# Altium schematic sheet sheet2_connectors.SchDoc
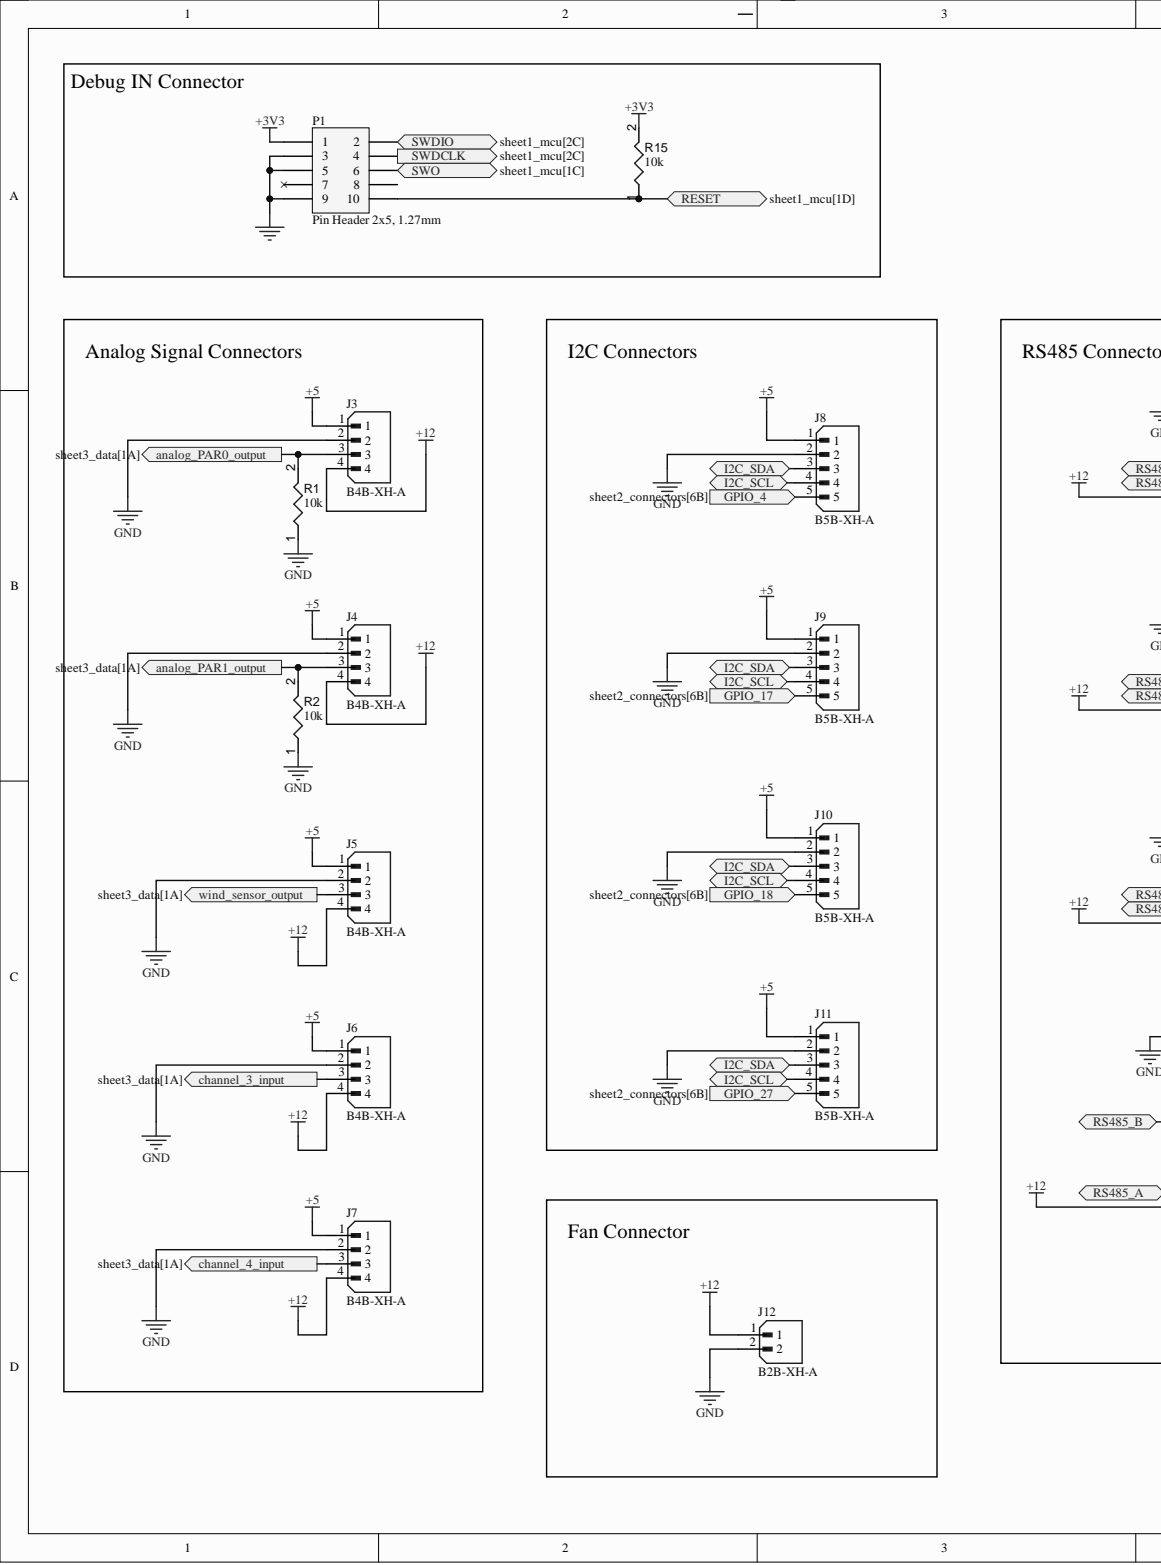
[diagram p0: sheet2_connectors.SchDoc, left]
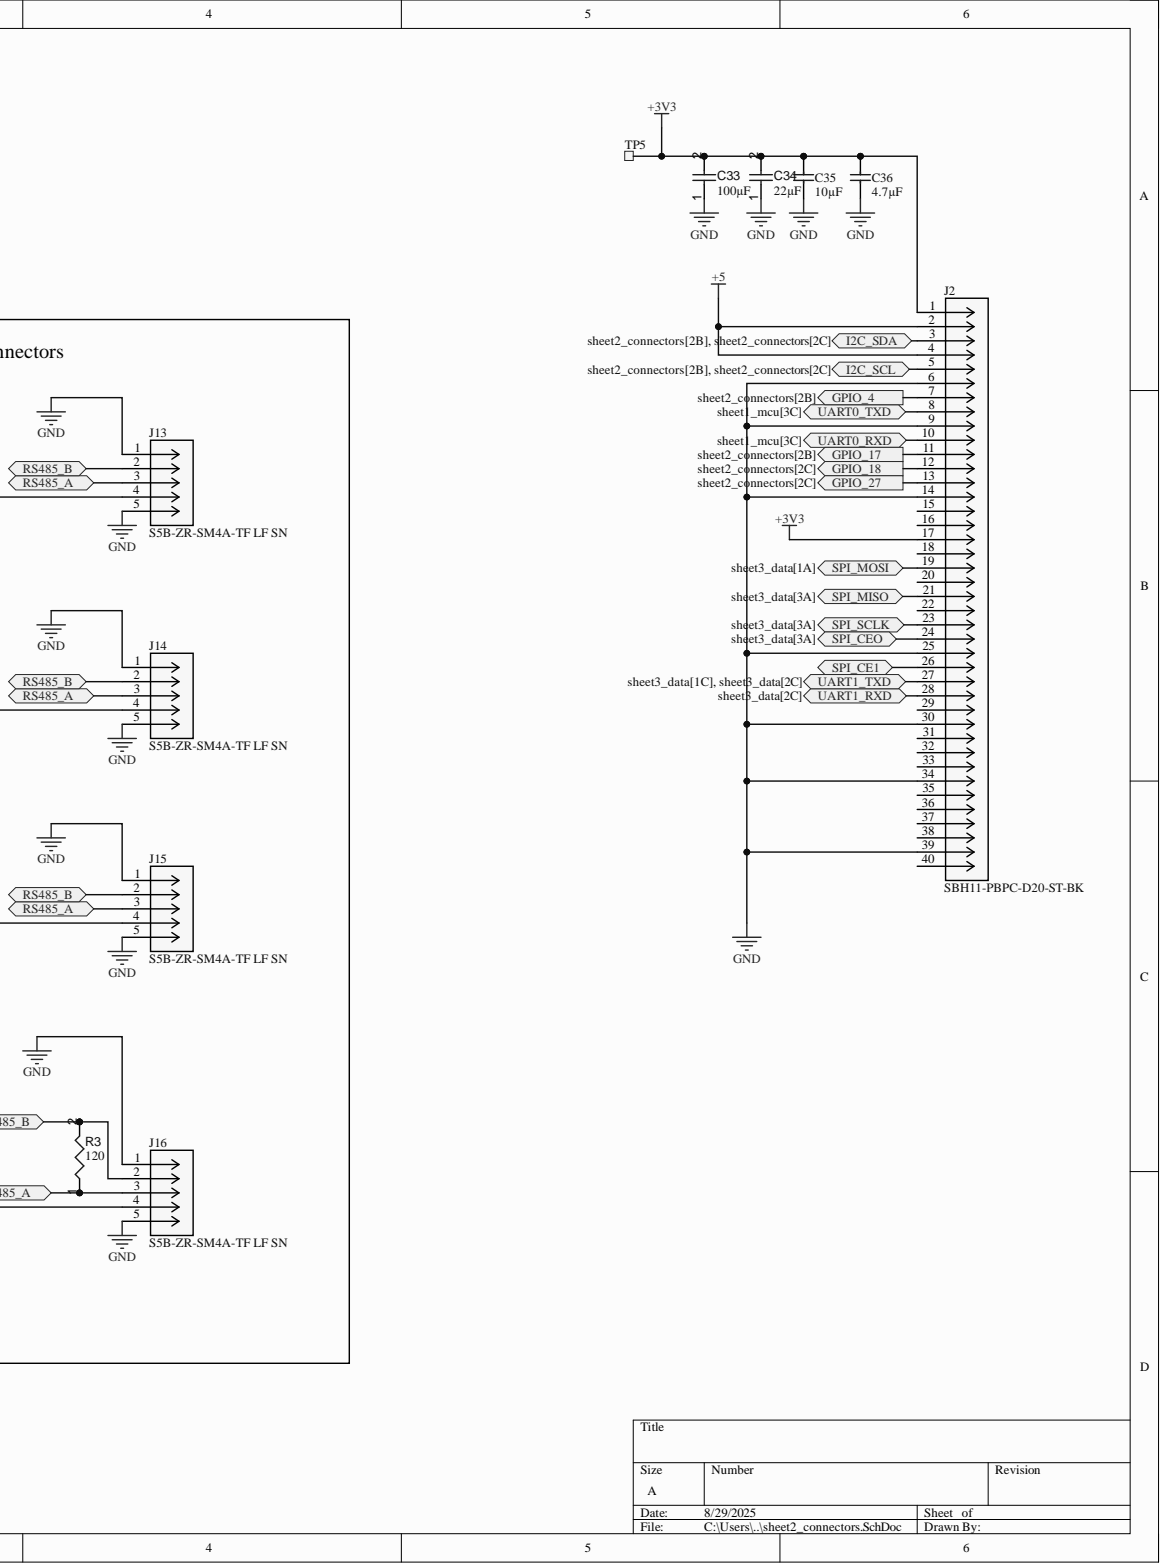
[diagram p1: sheet2_connectors.SchDoc, right]

## Parts
C33  value 100µF  [CAPC0805(2012)145_L]  (hidden comment: Capacitor 100µF +/-20% 6.3V 0805)
C34  value 22µF  [CAPC0805(2012)145_L]  (hidden comment: Capacitor 22µF +/-40% 16V 0805)
C35  10µF  [CAPC1608X06L]
C36  4.7µF  [CAPC1608X06L]
J2  SBH11-PBPC-D20-ST-BK  [CONN40_SBH11-PBPC-D20-ST-BK_SUL]
J3  B4B-XH-A  [JST_B4B-XH-A]
J4  B4B-XH-A  [JST_B4B-XH-A]
J5  B4B-XH-A  [JST_B4B-XH-A]
J6  B4B-XH-A  [JST_B4B-XH-A]
J7  B4B-XH-A  [JST_B4B-XH-A]
J8  B5B-XH-A  [JST_B5B-XH-A]
J9  B5B-XH-A  [JST_B5B-XH-A]
J10  B5B-XH-A  [JST_B5B-XH-A]
J11  B5B-XH-A  [JST_B5B-XH-A]
J12  B2B-XH-A  [JST_B2B-XH-A]
J13  S5B-ZR-SM4A-TF LF SN  [CONN_S5B-ZR-SM4A-TF_JST]
J14  S5B-ZR-SM4A-TF LF SN  [CONN_S5B-ZR-SM4A-TF_JST]
J15  S5B-ZR-SM4A-TF LF SN  [CONN_S5B-ZR-SM4A-TF_JST]
J16  S5B-ZR-SM4A-TF LF SN  [CONN_S5B-ZR-SM4A-TF_JST]
P1  Pin Header 2x5, 1.27mm  [HDR_2x5-SMD-1.27mm-K]
R1  value 10k  [RESC1005X40X25ML05T05]  (hidden comment: Resistor 10k +/-1% 0402 63 mW)
R2  value 10k  [RESC1005X40X25ML05T05]  (hidden comment: Resistor 10k +/-1% 0402 63 mW)
R3  value 120  [RESC0603(1608)_L]  (hidden comment: Resistor 120R +/-5 % 0603 0.1 W)
R15  value 10k  [RESC0402(1005)_L]  (hidden comment: Resistor 10K  +/-1 % 0402 63 mW)
TP5  Ø1.0mm,SMD  [TP_SMD_D1.0mm]  (value not drawn)  (no BOM)

## Ports
analog_PAR0_output, analog_PAR1_output, channel_3_input, channel_4_input, GPIO_4, GPIO_17, GPIO_18, GPIO_27, I2C_SCL, I2C_SDA, RESET, RS485_A, RS485_B, SPI_CE1, SPI_CEO, SPI_MISO, SPI_MOSI, SPI_SCLK, SWDCLK, SWDIO, SWO, UART0_RXD, UART0_TXD, UART1_RXD, UART1_TXD, wind_sensor_output

## Nets
+3V3: C33.2 C34.2 C35.2 C36.2 J2.1 J2.17 P1.1 R15.2 TP5.1
+5: J2.2 J2.4 J3.1 J4.1 J5.1 J6.1 J7.1 J8.1 J9.1 J10.1 J11.1
+12: J3.4 J4.4 J5.4 J6.4 J7.4 J12.1 J13.4 J14.4 J15.4 J16.4
GND: C33.1 C34.1 C35.1 C36.1 J2.6 J2.9 J2.14 J2.25 J2.30 J2.34 J2.39 J3.2 J4.2 J5.2 J6.2 J7.2 J8.2 J9.2 J10.2 J11.2 J12.2 J13.1 J13.5 J14.1 J14.5 J15.1 J15.5 J16.1 J16.5 P1.3 P1.5 P1.9 R1.1 R2.1
analog_PAR0_output: J3.3 R1.2 ; port analog_PAR0_output
analog_PAR1_output: J4.3 R2.2 ; port analog_PAR1_output
channel_3_input: J6.3 ; port channel_3_input
channel_4_input: J7.3 ; port channel_4_input
GPIO_4: J2.7 J8.5 ; port GPIO_4
GPIO_17: J2.11 J9.5 ; port GPIO_17
GPIO_18: J2.12 J10.5 ; port GPIO_18
GPIO_27: J2.13 J11.5 ; port GPIO_27
I2C_SCL: J2.5 J8.4 J9.4 J10.4 J11.4 ; port I2C_SCL
I2C_SDA: J2.3 J8.3 J9.3 J10.3 J11.3 ; port I2C_SDA
RESET: P1.10 R15.1 ; port RESET
RS485_A: J13.3 J14.3 J15.3 J16.3 R3.1 ; port RS485_A
RS485_B: J13.2 J14.2 J15.2 J16.2 R3.2 ; port RS485_B
SPI_CE1: J2.26 ; port SPI_CE1
SPI_CEO: J2.24 ; port SPI_CEO
SPI_MISO: J2.21 ; port SPI_MISO
SPI_MOSI: J2.19 ; port SPI_MOSI
SPI_SCLK: J2.23 ; port SPI_SCLK
SWDCLK: P1.4 ; port SWDCLK
SWDIO: P1.2 ; port SWDIO
SWO: P1.6 ; port SWO
UART0_RXD: J2.10 ; port UART0_RXD
UART0_TXD: J2.8 ; port UART0_TXD
UART1_RXD: J2.28 ; port UART1_RXD
UART1_TXD: J2.27 ; port UART1_TXD
wind_sensor_output: J5.3 ; port wind_sensor_output

Not connected: J2 15 16 18 20 22 29 31 32 33 35 36 37 38 40; P1 7 8

## Library data of the parts
C33 = CMP-001-00054-4: Chip Capacitor, 100µF +/-20%, 6.3V, 0805, Thickness 1.45 mm; Tolerance: 10%; Case/Package: 0805; Component Kind: Standard; Max Operating Temperature: 85°C; Min Operating Temperature: -55°C; Mounting Technology: SMT; Pins: 2; Voltage Rating: 6.3V; Library Name: Greenhouse Lysimeter Project
C34 = CMP-001-00081-4: Chip Capacitor, 22µF +/-40%, 16V, 0805, Thickness 1.45 mm; Tolerance: 5%; Case/Package: 0805; Component Kind: Standard; Max Operating Temperature: 85°C; Min Operating Temperature: -55°C; Mounting Technology: SMT; Pins: 2; Voltage Rating: 16V; Library Name: Greenhouse Lysimeter Project
C35 = C0603-10µ: Capacitor, X5R, ±20%; Manufacturer: N.A.; Part number IPS: 00000007785; Artikkelnr Noca: 20039234; Note: 6.3V; Pad Count: 2; Component Class: Capacitors; Component Kind: Standard; ComponentLink1Description: Datasheet; ComponentLink1URL: N.A.; ComponentLink2Description: Supplier link; ComponentLink2URL: N.A.; Footprint Doc: 0603; HelpURL: N.A.; LatestRevisionDate: 2011-01-17; Library Name: pca10040.IntLib; Manufacturer Part Number: N.A.; Part Number: C0603-10µ; Part number Norautron: N.A.; PartId: 100200275; Published: 2011-01-17; Supplier 1: N.A.; Supplier 2: N.A.; Supplier Part Number 1: N.A.; Supplier Part Number 2: N.A.; Value: 10µF; Author: rsk
C36 = C0603-4µ7-10V-X7S: Capacitor, X7S, ±10%, 10V; Manufacturer: N.A.; Part number IPS: 00000001779; Artikkelnr Noca: 20030013; Pad Count: 2; Component Class: Capacitors; Component Kind: Standard; ComponentLink1Description: Datasheet; ComponentLink1URL: N.A.; ComponentLink2Description: Supplier link; ComponentLink2URL: N.A.; Footprint Doc: 0603; HelpURL: N.A.; LatestRevisionDate: 2016-08-15; Library Name: pca10040.IntLib; Manufacturer Part Number: N.A.; Note: 10V; Part Number: C0603-4µ7-10V-X7S; Part number Norautron: N.A.; PartId: 100200351; Published: 2016-08-15; Supplier 1: Digi-Key; Supplier 2: N.A.; Supplier Part Number 1: 445-14258-1-ND; Supplier Part Number 2: N.A.; Value: 4.7µF; Author: rsk
J2 = CMP-010-000000-4: No Description Available; Mfr_Name: Sullins; Component Kind: Standard; Design Item ID: SBH11-PBPC-D20-ST-BK; RefDes: RefDes; Source: SBH11-PBPC-D20-ST-BK.SchLib; Type: Headers, Male Pins; Library Name: Greenhouse Lysimeter Project
J3-J7 = CMP-002-00073-1: Connector Header Through Hole 4 position 0.098 (2.50mm); MF: JST Sales; Case/Package: None; Check_prices: https://www.snapeda.com/parts/B4B-XH-A/JST/view-part/?ref=eda; Component Kind: Standard; Component Type: Standard; Design Item ID: B4B-XH-A; MP: B4B-XH-A; Pins: 4; SnapEDA_Link: https://www.snapeda.com/parts/B4B-XH-A/JST/view-part/?ref=snap; Source: B4B-XH-A.SchLib; Library Name: Greenhouse Lysimeter Project
J8-J11 = CMP-002-00076-1: Connector Header Through Hole 5 position 0.098 (2.50mm); MF: JST Sales; Case/Package: None; Check_prices: https://www.snapeda.com/parts/B5B-XH-A/JST+Sales+America+Inc./view-part/?ref=eda; Component Kind: Standard; Component Type: Standard; Design Item ID: B5B-XH-A; MP: B5B-XH-A; Pins: 5; SnapEDA_Link: https://www.snapeda.com/parts/B5B-XH-A/JST+Sales+America+Inc./view-part/?ref=snap; Source: B5B-XH-A.SchLib; Library Name: Greenhouse Lysimeter Project
J12 = CMP-032-000000-1: MF: {'manufacturer': u'JST Sales America Inc.'}; Availability: Unavailable; Component Kind: Standard; Component Type: Standard; Design Item ID: B2B-XH-A; MP: B2B-XH-A; Package: None; Pin Count: 2; Price: None; Source: B2B-XH-A.SchLib; Library Name: Greenhouse Lysimeter Project
J13-J16 = CMP-091-000000-1: No Description Available; Mfr_Name: JST; Component Kind: Standard; Design Item ID: S5B-ZR-SM4A-TF LF SN; RefDes: RefDes; Type: Type; Library Name: Greenhouse Lysimeter Project; Source: S5B-ZR-SM4A-TFLFSN.SchLib
P1 = Pin Header 2x5 SMD, 1.27mm, KS: Pin Header 2x5, 1.27mm (50mil), SMD, Keying Shroud; Manufacturer: W-CON; Manufacturer Part Number: 3132-10MS0BK00R1; Part number IPS: 00000009968; Artikkelnr Noca: 55010009; Note: N.A.; Pad Count: 10; Component Class: Connectors; Component Kind: Standard; ComponentLink1Description: Datasheet; ComponentLink1URL: N.A.; ComponentLink2Description: Supplier link; ComponentLink2URL: N.A.; Footprint Doc: HDR_2x5-SMD-1.27mm; HelpURL: \\hizz\pro\app9717\Datasheet\connectors\W-CON\3132-XXMXXBK00XX.pdf; LatestRevisionDate: 2016-04-21; Library Name: pca10040.IntLib; Part Number: Pin Header 2x5 SMD, 1.27mm, KS; Part number Norautron: N.A.; PartId: 100500261; Published: 2016-01-22; Supplier 1: Cabcon; Supplier 2: Samtec; Supplier Part Number 1: 3132-10MS0BK00R1; Supplier Part Number 2: FTSH-105-01-F-DV-K; Value: Pin Header 2x5, 1.27mm; Author: rsk
R1 R2 = CMP-009-00019-5: Chip Resistor, 10 KOhm, +/- 1%, 63 mW, -55 to 155 degC, 0402 (1005 Metric); Power: 63mW; Voltage Rating: 50V; Case/Package: 0402; Component Kind: Standard; Max Operating Temperature: 155°C; Min Operating Temperature: -55°C; Mounting Technology: SM; Pins: 2; RoHS Compliant: True; Tolerance: 1%; Library Name: Greenhouse Lysimeter Project
R3 = CMP-009-00176-3: Chip Resistor, 120 Ohms, +/-5 %, 0.1 W, -55 to 155 degC, 0603; Power: 100mW; Tolerance: 5%; Case/Package: 0603; Component Kind: Standard; Max Operating Temperature: 155°C; Min Operating Temperature: -55°C; Pins: 2; Library Name: Greenhouse Lysimeter Project
R15 = CMP-009-00149-3: Chip Resistor, 10 kOhms, +/-1 %, 63 mW, -55 to 125 degC, 0402; Power: 63mW; Tolerance: 1%; Case/Package: 0402; Component Kind: Standard; Max Operating Temperature: 155°C; Min Operating Temperature: -55°C; Pins: 2; Library Name: Greenhouse Lysimeter Project
TP5 = TP_SMD_Ø1.0MM: 1.0mm circular SMD testpad; Manufacturer: Nordic Semiconductor; Artikkelnr Noca: N.A.; Pad Count: 1; Component Class: Connectors; ComponentLink1Description: Datasheet; ComponentLink1URL: N.A.; ComponentLink2Description: Supplier link; ComponentLink2URL: N.A.; Footprint Doc: TP_SMD_Ø1.0mm; HelpURL: N.A.; LatestRevisionDate: 2021-03-05; Library Name: pca10040.IntLib; Manufacturer Part Number: N.A.; Note: N.A.; Part Number: TP_SMD_Ø1.0MM; Part number IPS: N.A.; Part number Norautron: N.A.; PartId: 100500475; Published: 2013-06-11; Supplier 1: N.A.; Supplier 2: N.A.; Supplier Part Number 1: N.A.; Supplier Part Number 2: N.A.; Value: Ø1.0mm,SMD; Author: rsk
